# Altium schematic sheet PINOUT.SchDoc
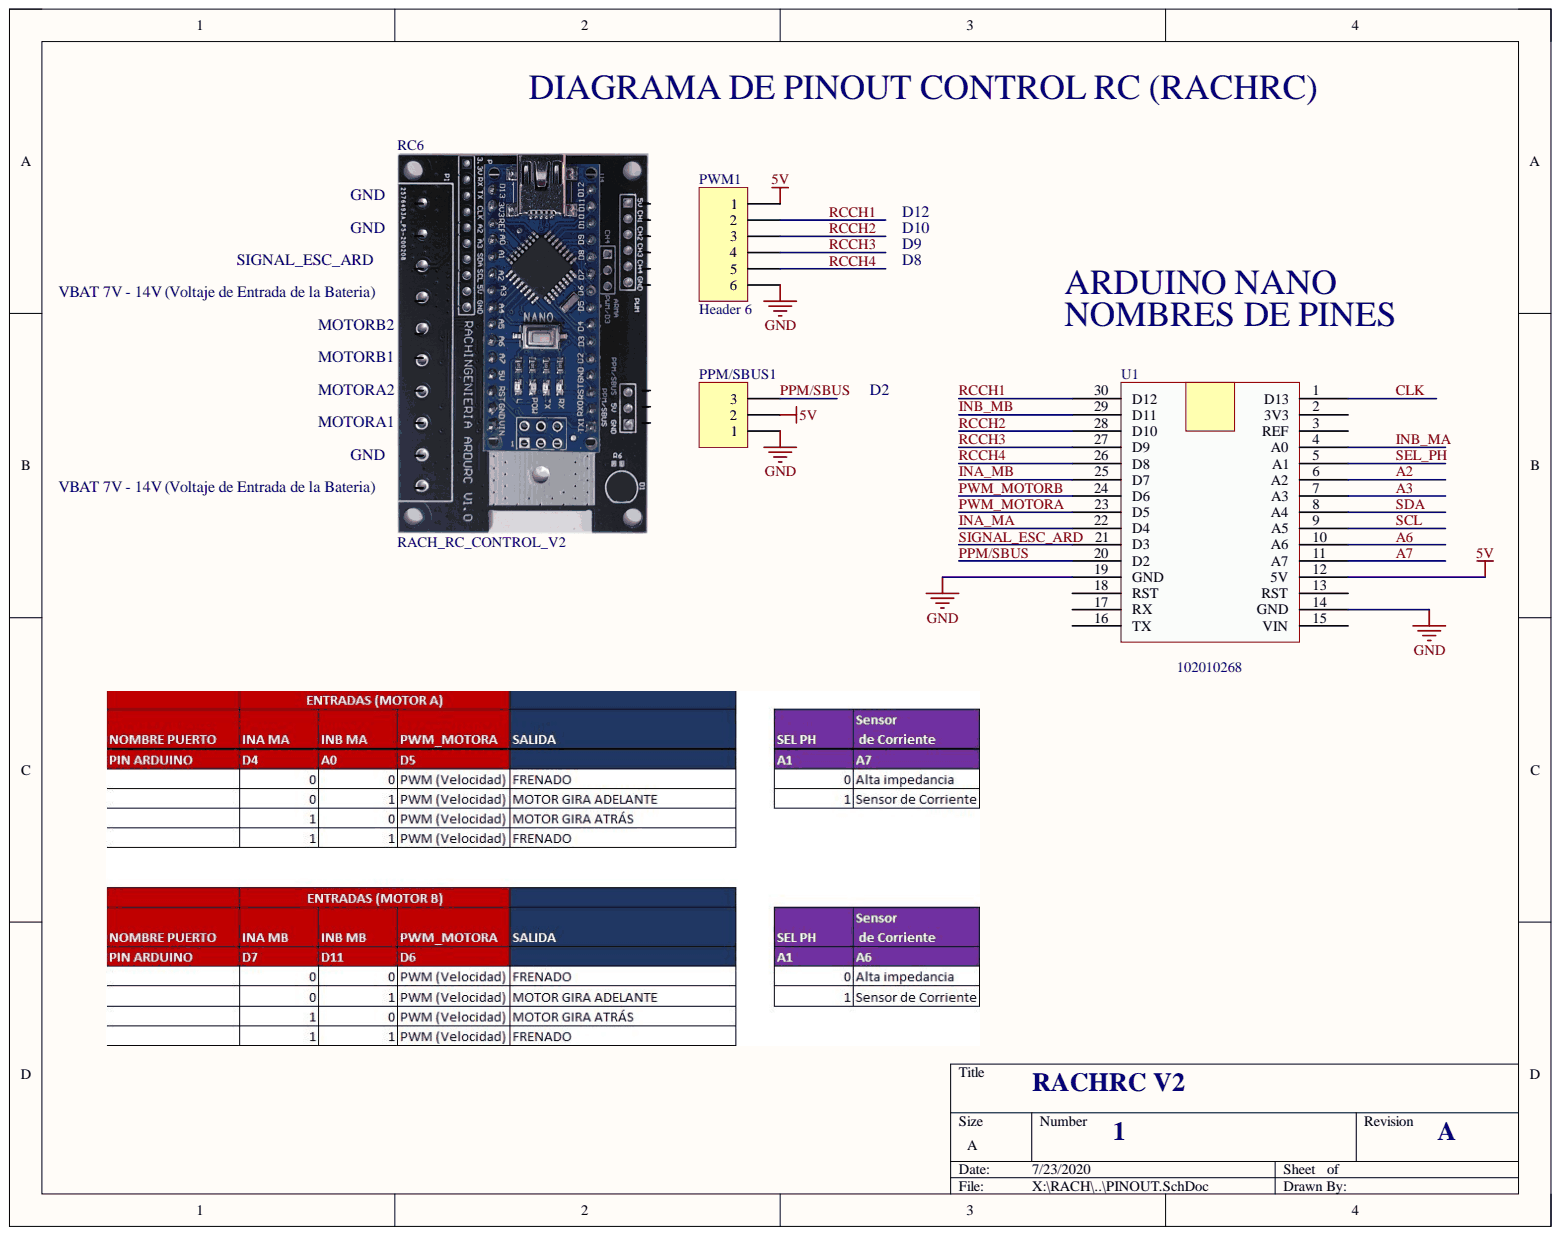
[diagram p0: PINOUT.SchDoc]

## Parts
PPM/SBUS1  Not Part  [HDR1X3]  (value not drawn)
PWM1  Header 6  [HDR1X6]
RC6  RACH_RC_CONTROL_V2
U1  102010268  [ARDUINO]

## Nets
5V: PPM/SBUS1.2 PWM1.1 U1.12(5V)
GND: PPM/SBUS1.1 PWM1.6 U1.14(GND) U1.19(GND)
A2: U1.6(A2)
A3: U1.7(A3)
A6: U1.10(A6)
A7: U1.11(A7)
CLK: U1.1(D13)
INA_MA: U1.22(D4)
INA_MB: U1.25(D7)
INB_MA: U1.4(A0)
INB_MB: U1.29(D11)
PPM/SBUS: PPM/SBUS1.3 U1.20(D2)
PWM_MOTORA: U1.23(D5)
PWM_MOTORB: U1.24(D6)
RCCH1: PWM1.2 U1.30(D12)
RCCH2: PWM1.3 U1.28(D10)
RCCH3: PWM1.4 U1.27(D9)
RCCH4: PWM1.5 U1.26(D8)
SCL: U1.9(A5)
SDA: U1.8(A4)
SEL_PH: U1.5(A1)
SIGNAL_ESC_ARD: U1.21(D3)

Not connected: RC6 2 3 4 5 6 7 8 9 10 11 12 13 14 15 16 17 18 19 20; U1 2(3V3) 3(REF) 13(RST) 15(VIN) 16(TX) 17(RX) 18(RST)

## Library data of the parts
PPM/SBUS1 = Header 3: Conector EDF; Component Kind: Standard; Library Name: Miscellaneous Connectors.IntLib; Manufacturer Part Number 1: Not Part; Signal Integrity: Connector; Special Notes: Not Part
PWM1 = Header 6: Header, 6-Pin; Component Kind: Standard; Library Name: Miscellaneous Connectors.IntLib; Signal Integrity: Connector
U1 = ARDUINO NANO: ARDUINO NANO ATMEGA328 EVAL BRD; Part Number: 713-102010268; Component Kind: Standard; Manufacturer: Arduino; Manufacturer 1: Arduino; Manufacturer Part Number 1: 102010268; Manufacturer PN: 102010268; Library Name: VELTRONICS.SCHLIB
RC6 = RACH_RC_CONTROL_V2: Component Kind: Standard; Library Name: Componentes.SchLib
